# Altium schematic sheet Core.SchDoc
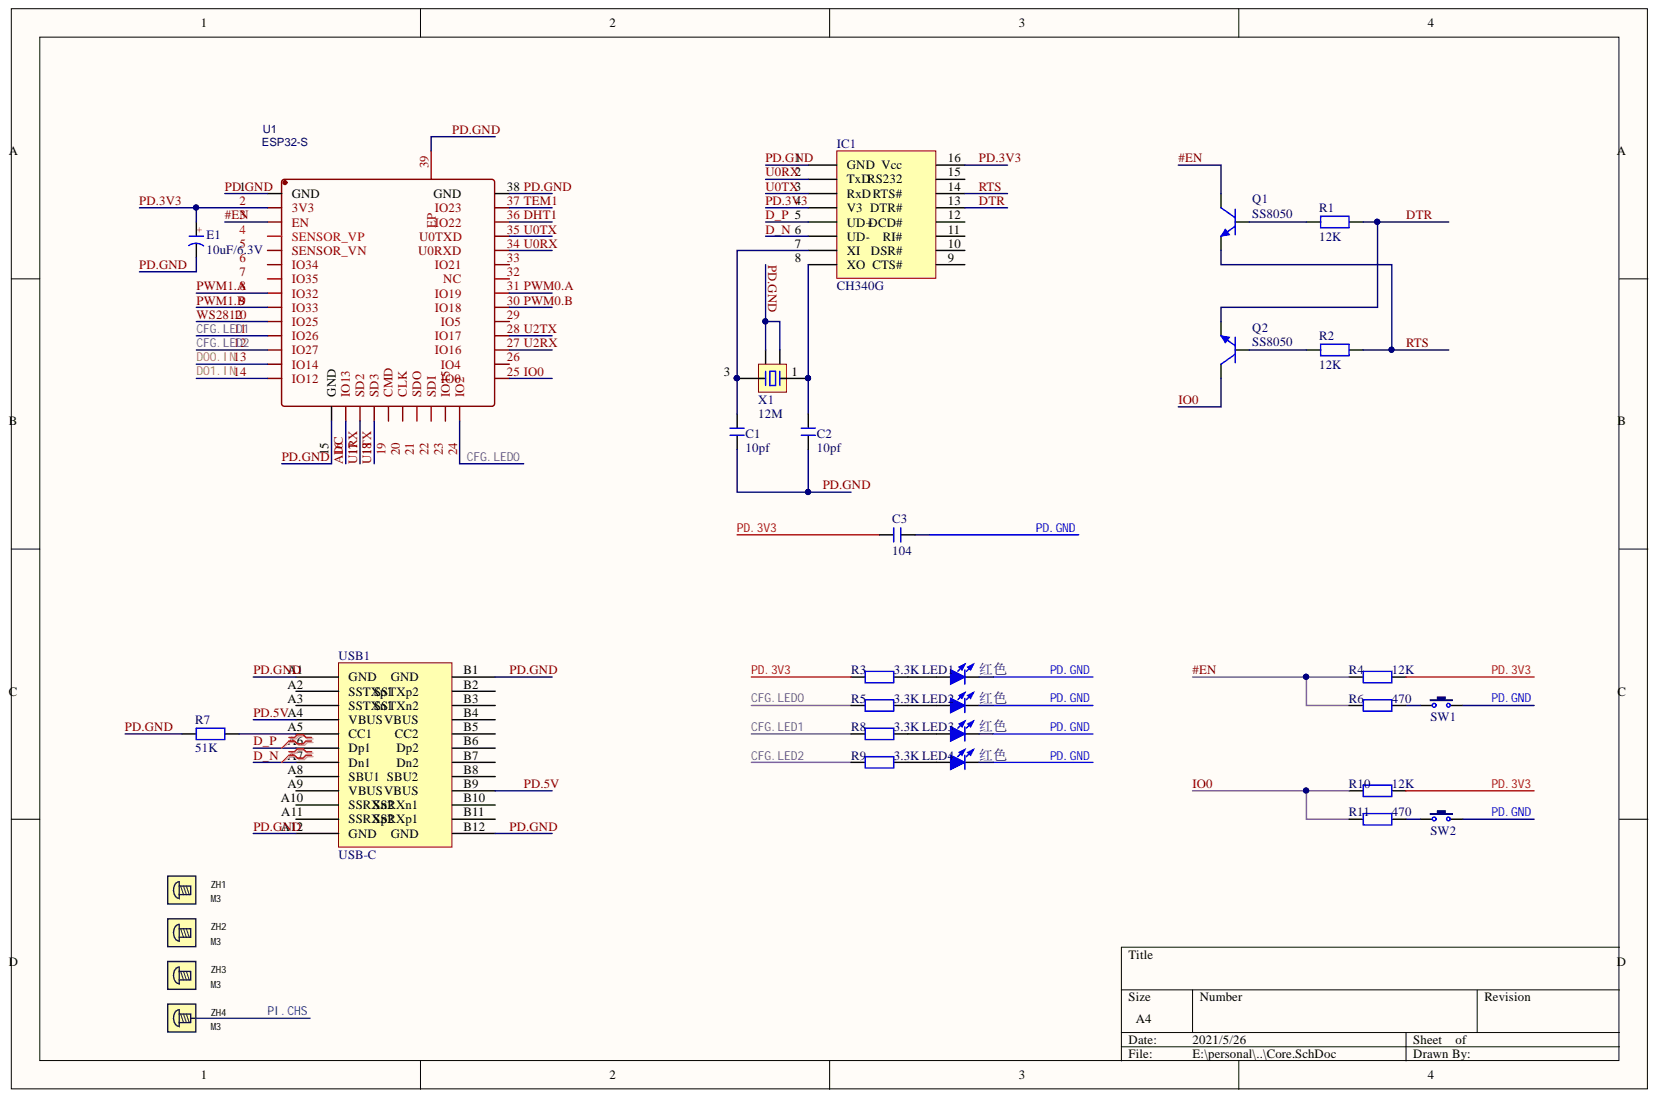
[diagram p0: Core.SchDoc]

## Parts
C1  10pf  [C 0603]
C2  10pf  [C 0603]
C3  104  [C 0603]
E1  10uF/6.3V  [CD B(3528)]
IC1  CH340G  [SOP16_L]
LED1  红色  [LED 0603R]
LED2  红色  [LED 0603R]
LED3  红色  [LED 0603R]
LED4  红色  [LED 0603R]
Q1  SS8050  [SOT23-3N]
Q2  SS8050  [SOT23-3N]
R1  12K  [R 0603]
R2  12K  [R 0603]
R3  3.3K  [R 0603]
R4  12K  [R 0603]
R5  3.3K  [R 0603]
R6  470  [R 0603]
R7  51K  [R 0603]
R8  3.3K  [R 0603]
R9  3.3K  [R 0603]
R10  12K  [R 0603]
R11  470  [R 0603]
SW1  3x6x5  [TSW SMD-3*6*2.5]  (value not drawn)
SW2  3x6x5  [TSW SMD-3*6*2.5]  (value not drawn)
U1  ESP32-S  [ESP32-S]
USB1  USB-C  [TYPE-C-31-M-12]
X1  12M  [XTAL-5032]
ZH1  M3  [ZH_Mount_NPH_M3.0]  (no BOM)
ZH2  M3  [ZH_Mount_NPH_M3.0]  (no BOM)
ZH3  M3  [ZH_Mount_NPH_M3.0]  (no BOM)
ZH4  M3  [ZH_Mount_PH_M3.0]  (no BOM)

## Nets
#EN: Q1.3 R4.2 R6.2 U1.3(EN)
ADC: U1.16(IO13)
CFG.LED0: R5.2 U1.24(IO2)
CFG.LED1: R8.2 U1.11(IO26)
CFG.LED2: R9.2 U1.12(IO27)
D_N: IC1.6(UD-) USB1.A7(Dn1)
D_P: IC1.5(UD+) USB1.A6(Dp1)
DHT1: U1.36
DO0.IN: U1.13(IO14)
DO1.IN: U1.14(IO12)
DTR: IC1.13 Q2.2 R1.2
IO0: Q2.3 R10.2 R11.2 U1.25
PD.3V3: C3.2 E1.1 IC1.4(V3) IC1.16 R3.2 R4.1 R10.1 U1.2(3V3)
PD.5V: USB1.A4 USB1.B9
PD.GND: C1.1 C2.1 C3.1 E1.2 IC1.1(GND) LED1.2 LED2.2 LED3.2 LED4.2 R7.2 SW1.2 SW2.2 U1.1(GND) U1.15(GND) U1.38 U1.39 USB1.A1(GND) USB1.A12(GND) USB1.B1 USB1.B12 X1.2 X1.4
PI.CHS: ZH4.H
PWM0.A: U1.31
PWM0.B: U1.30
PWM1.A: U1.8(IO32)
PWM1.B: U1.9(IO33)
RTS: IC1.14 Q1.2 R2.2
TEM1: U1.37
U0RX: IC1.2(TxD) U1.34
U0TX: IC1.3 U1.35
U1RX: U1.17(SD2)
U1TX: U1.18(SD3)
U2RX: U1.27
U2TX: U1.28
WS2812: U1.10(IO25)
NetC1_2: C1.2 IC1.7(XI) X1.3
NetC2_2: C2.2 IC1.8(XO) X1.1
NetLED1_1: LED1.1 R3.1
NetLED2_1: LED2.1 R5.1
NetLED3_1: LED3.1 R8.1
NetLED4_1: LED4.1 R9.1
NetQ1_1: Q1.1 R1.1
NetQ2_1: Q2.1 R2.1
NetR6_1: R6.1 SW1.1
NetR7_1: R7.1 USB1.A5(CC1)
NetR11_1: R11.1 SW2.1

Not connected: IC1 9 10 11 12 15; U1 4(SENSOR_VP) 5(SENSOR_VN) 6(IO34) 7(IO35) 19(CMD) 20(CLK) 21(SDO) 22(SDI) 23(IO15) 26 29 32 33; USB1 A2(SSTXp1) A3(SSTXn1) A8(SBU1) A9 A10(SSRXn2) A11(SSRXp2) B2 B3 B4 B5 B6 B7 B8 B10 B11

## Library data of the parts
C1-C3 = C: 无极性贴片电容; 版权归属: 源创客; BOM名称: 无极性贴片电容; Component Kind: Standard; Library Name: 电阻-电容-电感.SchLib; 学习资源: www.ooocke.com
E1 = C-CD: 贴片钽电容; 版权归属: 源创客; BOM名称: 贴片钽电容; Component Kind: Standard; Library Name: 3.1   - 电阻-电容-电感.SchLib; 学习资源: www.ooocke.com
IC1 = CH340G: 串口转USB; 版权归属: 源创客; BOM名称: 串口转USB; Component Kind: Standard; Library Name: IC芯片.SchLib; 学习资源: www.ooocke.com
LED1-LED4 = LED-SMD: 贴片LED; 版权归属: 源创客; BOM名称: 贴片LED; Component Kind: Standard; Library Name: LED.SchLib; 学习资源: www.ooocke.com
Q1 Q2 = 8050-SMD: 高频放大-NPN型; 属性: 40V 1.5A 1W 100MHZ; 版权归属: 源创客; BOM名称: 高频放大-NPN型; Component Kind: Standard; Library Name: 6.2   - 三极管.SchLib; 学习资源: www.ooocke.com
R1-R11 = R: 贴片电阻; 版权归属: 源创客; BOM名称: 贴片电阻; Component Kind: Standard; Library Name: 3.1   - 电阻-电容-电感.SchLib; 学习资源: www.ooocke.com
SW1 SW2 = TSW 3x6: 3x6轻触开关; 版权归属: 源创客; BOM名称: 3x6轻触开关; Component Kind: Standard; Library Name: 按键-开关.SchLib; 学习资源: www.ooocke.com
U1 = ESP32-S: BOM_Manufacturer: Ai-Thinker; BOM_Paste Type: expand; Contributor: LCSC; BOM_Manufacturer Part: ESP32-S; BOM_Supplier: LCSC; BOM_Supplier Part: C277944; Component Kind: Standard; spicePre: U; spiceSymbolName: ESP32-S
USB1 = USB-C/S: USB-C型母接口; 版权归属: 源创客; BOM名称: USB-C型母接口; Component Kind: Standard; Library Name: 4.1   - 接口类.SchLib; 学习资源: www.ooocke.com
X1 = XTAL-4: 4脚晶振; 版权归属: 源创客; BOM名称: 4脚晶振; Component Kind: Standard; Library Name: 保险丝-晶振.SchLib; 学习资源: www.ooocke.com
ZH1-ZH3 = Misc_Mount: ZH; Library Name: Basic.SchLib
ZH4 = Misc_Mount_Plated: ZH; ARG-CON-Dir: YES; Library Name: Basic.SchLib
